# Altium schematic sheet High_Voltage.SchDoc(High_Voltage)
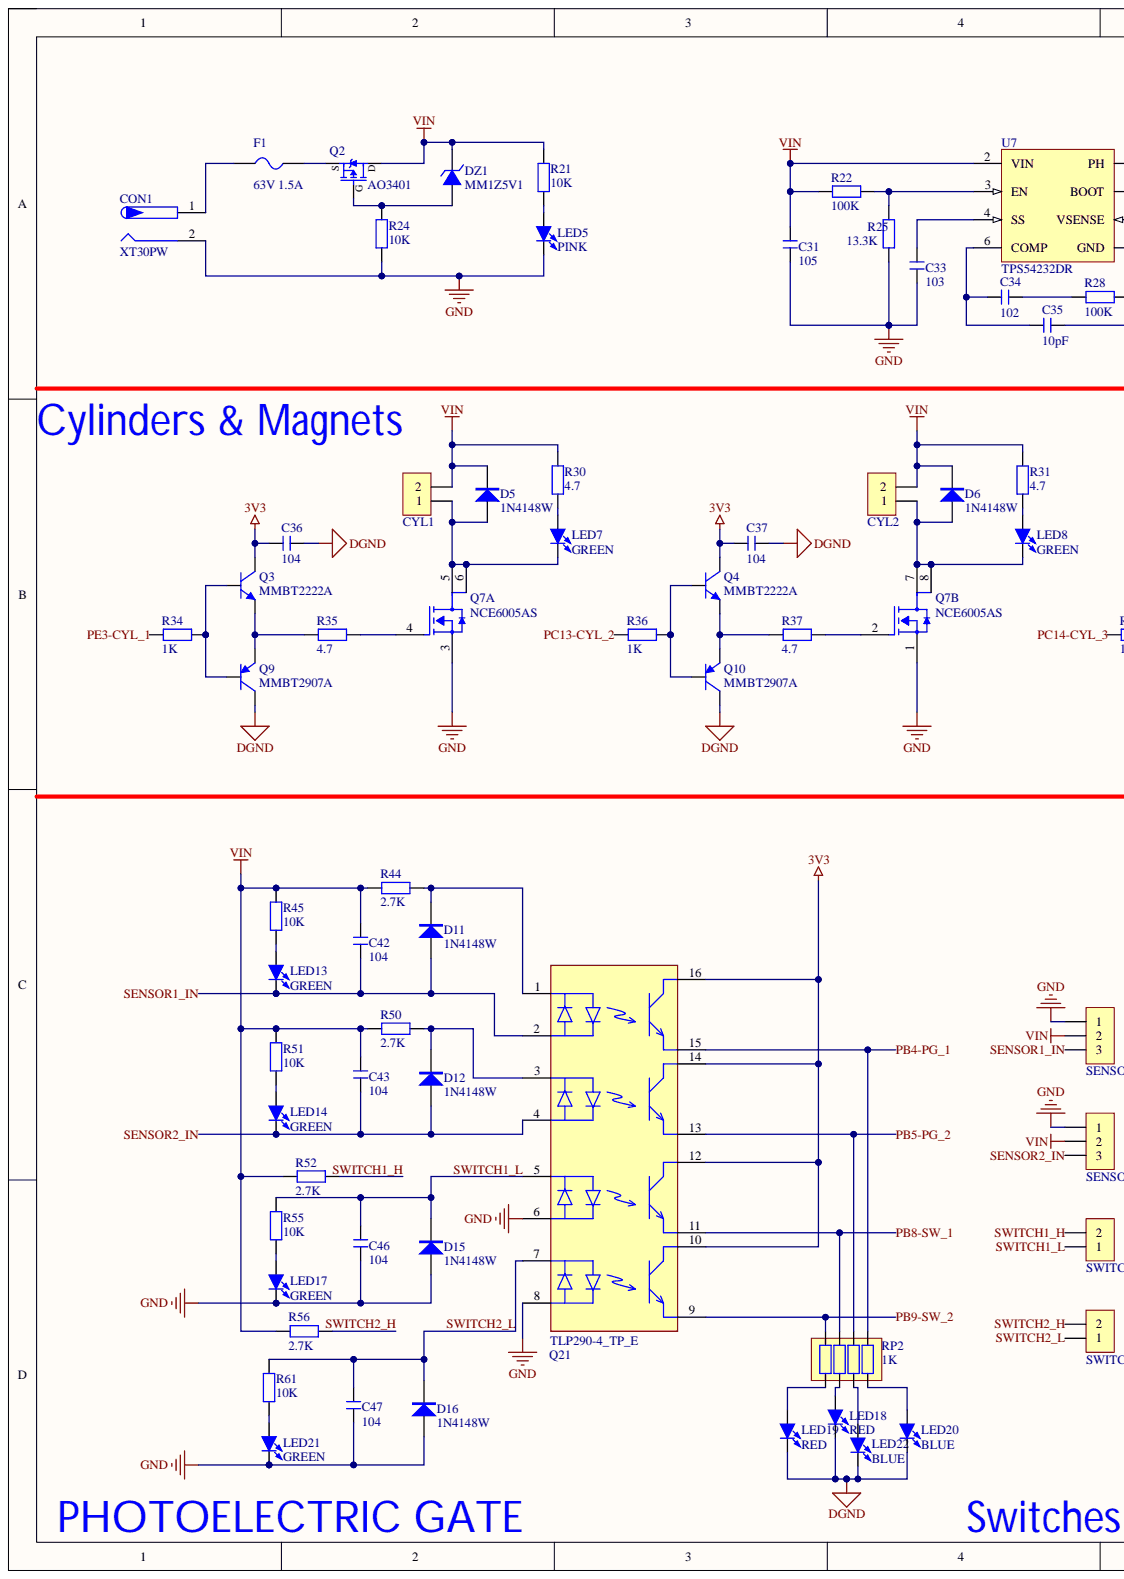
[diagram p0: High_Voltage.SchDoc, left]
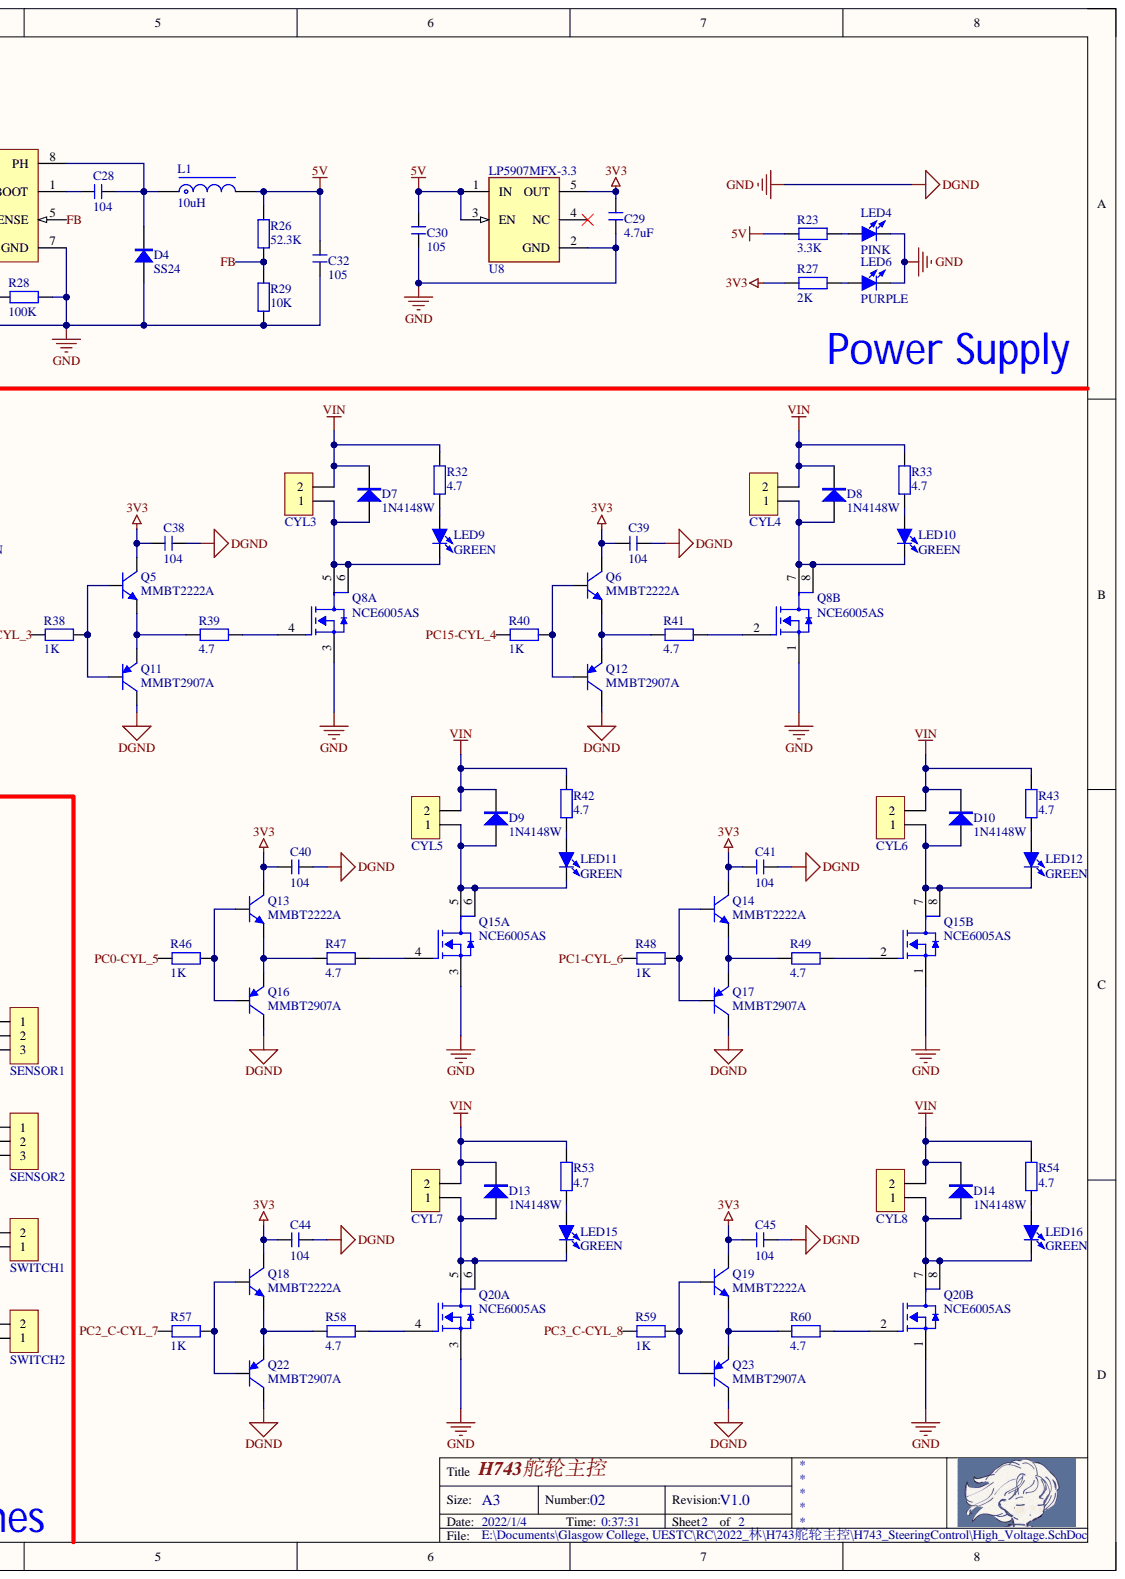
[diagram p1: High_Voltage.SchDoc, right]

## Parts
C28  104  [C 0603_L]
C29  4.7uF  [C 0603_L]
C30  105  [C 0603_L]
C31  105  [C 0805_L]
C32  105  [C 0805_L]
C33  103  [C 0603_L]
C34  102  [C 0603_L]
C35  10pF  [C 0603_L]
C36  104  [C 0603_L]
C37  104  [C 0603_L]
C38  104  [C 0603_L]
C39  104  [C 0603_L]
C40  104  [C 0603_L]
C41  104  [C 0603_L]
C42  104  [C 0603_L]
C43  104  [C 0603_L]
C44  104  [C 0603_L]
C45  104  [C 0603_L]
C46  104  [C 0603_L]
C47  104  [C 0603_L]
CON1  XT30PW  [XT30PW-F]
CYL1  HDR-1X2  [XH2.54-LS-2P]  (value not drawn)
CYL2  HDR-1X2  [XH2.54-LS-2P]  (value not drawn)
CYL3  HDR-1X2  [XH2.54-LS-2P]  (value not drawn)
CYL4  HDR-1X2  [XH2.54-LS-2P]  (value not drawn)
CYL5  HDR-1X2  [XH2.54-LS-2P]  (value not drawn)
CYL6  HDR-1X2  [XH2.54-LS-2P]  (value not drawn)
CYL7  HDR-1X2  [XH2.54-LS-2P]  (value not drawn)
CYL8  HDR-1X2  [XH2.54-LS-2P]  (value not drawn)
D4  SS24  [SMA]
D5  1N4148W  [SOD-123]
D6  1N4148W  [SOD-123]
D7  1N4148W  [SOD-123]
D8  1N4148W  [SOD-123]
D9  1N4148W  [SOD-123]
D10  1N4148W  [SOD-123]
D11  1N4148W  [SOD-123]
D12  1N4148W  [SOD-123]
D13  1N4148W  [SOD-123]
D14  1N4148W  [SOD-123]
D15  1N4148W  [SOD-123]
D16  1N4148W  [SOD-123]
DZ1  MM1Z5V1  [SOD-123]
F1  63V 1.5A  [FU 1206]
L1  10uH  [NR5040]
LED4  PINK  [LED 0603Y]
LED5  PINK  [LED 0603R]
LED6  PURPLE  [LED 0603Y]
LED7  GREEN  [LED 0603G]
LED8  GREEN  [LED 0603G]
LED9  GREEN  [LED 0603G]
LED10  GREEN  [LED 0603G]
LED11  GREEN  [LED 0603G]
LED12  GREEN  [LED 0603G]
LED13  GREEN  [LED 0603G]
LED14  GREEN  [LED 0603G]
LED15  GREEN  [LED 0603G]
LED16  GREEN  [LED 0603G]
LED17  GREEN  [LED 0603G]
LED18  RED  [LED 0603R]
LED19  RED  [LED 0603R]
LED20  BLUE  [LED 0603B]
LED21  GREEN  [LED 0603G]
LED22  BLUE  [LED 0603B]
Q2  AO3401  [SOT23-3N]
Q3  MMBT2222A  [SOT23-3L]
Q4  MMBT2222A  [SOT23-3L]
Q5  MMBT2222A  [SOT23-3L]
Q6  MMBT2222A  [SOT23-3L]
Q7  NCE6005AS  [Alpha_&_Omega_Semiconductor_Inc_SOIC-8_IPC_C]  (parts A,B)
Q8  NCE6005AS  [Alpha_&_Omega_Semiconductor_Inc_SOIC-8_IPC_C]  (parts A,B)
Q9  MMBT2907A  [SOT23-3L]
Q10  MMBT2907A  [SOT23-3L]
Q11  MMBT2907A  [SOT23-3L]
Q12  MMBT2907A  [SOT23-3L]
Q13  MMBT2222A  [SOT23-3L]
Q14  MMBT2222A  [SOT23-3L]
Q15  NCE6005AS  [Alpha_&_Omega_Semiconductor_Inc_SOIC-8_IPC_C]  (parts A,B)
Q16  MMBT2907A  [SOT23-3L]
Q17  MMBT2907A  [SOT23-3L]
Q18  MMBT2222A  [SOT23-3L]
Q19  MMBT2222A  [SOT23-3L]
Q20  NCE6005AS  [Alpha_&_Omega_Semiconductor_Inc_SOIC-8_IPC_C]  (parts A,B)
Q21  TLP290-4_TP_E  [SOP16_L]
Q22  MMBT2907A  [SOT23-3L]
Q23  MMBT2907A  [SOT23-3L]
R21  10K  [R 0603_L]
R22  100K  [R 0603_L]
R23  3.3K  [R 0603_L]
R24  10K  [R 0603_L]
R25  13.3K  [R 0603_L]
R26  52.3K  [R 0603_L]
R27  2K  [R 0603_L]
R28  100K  [R 0603_L]
R29  10K  [R 0603_L]
R30  4.7  [R 0603_L]
R31  4.7  [R 0603_L]
R32  4.7  [R 0603_L]
R33  4.7  [R 0603_L]
R34  1K  [R 0603_L]
R35  4.7  [R 0603_L]
R36  1K  [R 0603_L]
R37  4.7  [R 0603_L]
R38  1K  [R 0603_L]
R39  4.7  [R 0603_L]
R40  1K  [R 0603_L]
R41  4.7  [R 0603_L]
R42  4.7  [R 0603_L]
R43  4.7  [R 0603_L]
R44  2.7K  [R 0603_L]
R45  10K  [R 0603_L]
R46  1K  [R 0603_L]
R47  4.7  [R 0603_L]
R48  1K  [R 0603_L]
R49  4.7  [R 0603_L]
R50  2.7K  [R 0603_L]
R51  10K  [R 0603_L]
R52  2.7K  [R 0603_L]
R53  4.7  [R 0603_L]
R54  4.7  [R 0603_L]
R55  10K  [R 0603_L]
R56  2.7K  [R 0603_L]
R57  1K  [R 0603_L]
R58  4.7  [R 0603_L]
R59  1K  [R 0603_L]
R60  4.7  [R 0603_L]
R61  10K  [R 0603_L]
RP2  1K  [RCA-8P4R-0603]
SENSOR1  HDR-1X3  [XH2.54-LS-3P]  (value not drawn)
SENSOR2  HDR-1X3  [XH2.54-LS-3P]  (value not drawn)
SWITCH1  HDR-1X2  [XH2.54-LS-2P]  (value not drawn)
SWITCH2  HDR-1X2  [XH2.54-LS-2P]  (value not drawn)
U7  TPS54232DR  [SOP8_N]
U8  LP5907MFX-3.3  [Diodes_SOT25_MFG]

## Nets
3V3: C29.2 C36.1 C37.1 C38.1 C39.1 C40.1 C41.1 C44.1 C45.1 Q3.3 Q4.3 Q5.3 Q6.3 Q13.3 Q14.3 Q18.3 Q19.3 Q21.10 Q21.12 Q21.14 Q21.16 R27.2 U8.5(OUT)
5V: C30.2 C32.2 L1.2 R23.2 R26.1 U8.1(IN) U8.3(EN)
DGND: C29.1 C30.1 C31.1 C32.1 C33.2 C35.2 C36.2 C37.2 C38.2 C39.2 C40.2 C41.2 C44.2 C45.2 C46.1 C47.1 CON1.2 D4.1 D15.1 D16.1 LED4.2 LED5.2 LED6.2 LED17.2 LED18.2 LED19.2 LED20.2 LED21.2 LED22.2 Q7.1 Q7.3 Q8.1 Q8.3 Q9.3 Q10.3 Q11.3 Q12.3 Q15.1 Q15.3 Q16.3 Q17.3 Q20.1 Q20.3 Q21.6 Q21.8 Q22.3 Q23.3 R24.1 R25.1 R28.1 R29.2 SENSOR1.1 SENSOR2.1 U7.7(GND) U8.2(GND)
VIN: C31.2 C42.2 C43.2 CYL1.2 CYL2.2 CYL3.2 CYL4.2 CYL5.2 CYL6.2 CYL7.2 CYL8.2 D5.2 D6.2 D7.2 D8.2 D9.2 D10.2 D13.2 D14.2 DZ1.2 Q2.3 R21.2 R22.2 R30.2 R31.2 R32.2 R33.2 R42.2 R43.2 R44.1 R45.2 R50.1 R51.2 R52.1 R53.2 R54.2 R56.1 SENSOR1.2 SENSOR2.2 U7.2(VIN)
FB: R26.2 R29.1 U7.5(VSENSE)
PB4-PG_1: Q21.15 RP2.5
PB5-PG_2: Q21.13 RP2.6
PB8-SW_1: Q21.11 RP2.7
PB9-SW_2: Q21.9 RP2.8
PC0-CYL_5: R46.1
PC1-CYL_6: R48.1
PC2_C-CYL_7: R57.1
PC3_C-CYL_8: R59.1
PC13-CYL_2: R36.1
PC14-CYL_3: R38.1
PC15-CYL_4: R40.1
PE3-CYL_1: R34.1
SENSOR1_IN: C42.1 D11.1 LED13.2 Q21.2 SENSOR1.3
SENSOR2_IN: C43.1 D12.1 LED14.2 Q21.4 SENSOR2.3
SWITCH1_H: R52.2 SWITCH1.2
SWITCH1_L: C46.2 D15.2 Q21.5 R55.2 SWITCH1.1
SWITCH2_H: R56.2 SWITCH2.2
SWITCH2_L: C47.2 D16.2 Q21.7 R61.2 SWITCH2.1
NetC28_1: C28.1 U7.1(BOOT)
NetC28_2: C28.2 D4.2 L1.1 U7.8(PH)
NetC33_1: C33.1 U7.4(SS)
NetC34_1: C34.1 C35.1 U7.6(COMP)
NetC34_2: C34.2 R28.2
NetCON1_1: CON1.1 F1.1
NetCYL1_1: CYL1.1 D5.1 LED7.2 Q7.5 Q7.6
NetCYL2_1: CYL2.1 D6.1 LED8.2 Q7.7 Q7.8
NetCYL3_1: CYL3.1 D7.1 LED9.2 Q8.5 Q8.6
NetCYL4_1: CYL4.1 D8.1 LED10.2 Q8.7 Q8.8
NetCYL5_1: CYL5.1 D9.1 LED11.2 Q15.5 Q15.6
NetCYL6_1: CYL6.1 D10.1 LED12.2 Q15.7 Q15.8
NetCYL7_1: CYL7.1 D13.1 LED15.2 Q20.5 Q20.6
NetCYL8_1: CYL8.1 D14.1 LED16.2 Q20.7 Q20.8
NetD11_2: D11.2 Q21.1 R44.2
NetD12_2: D12.2 Q21.3 R50.2
NetDZ1_1: DZ1.1 Q2.1 R24.2
NetF1_2: F1.2 Q2.2
NetLED4_1: LED4.1 R23.1
NetLED5_1: LED5.1 R21.1
NetLED6_1: LED6.1 R27.1
NetLED7_1: LED7.1 R30.1
NetLED8_1: LED8.1 R31.1
NetLED9_1: LED9.1 R32.1
NetLED10_1: LED10.1 R33.1
NetLED11_1: LED11.1 R42.1
NetLED12_1: LED12.1 R43.1
NetLED13_1: LED13.1 R45.1
NetLED14_1: LED14.1 R51.1
NetLED15_1: LED15.1 R53.1
NetLED16_1: LED16.1 R54.1
NetLED17_1: LED17.1 R55.1
NetLED18_1: LED18.1 RP2.2
NetLED19_1: LED19.1 RP2.1
NetLED20_1: LED20.1 RP2.4
NetLED21_1: LED21.1 R61.1
NetLED22_1: LED22.1 RP2.3
NetQ3_1: Q3.1 Q9.1 R34.2
NetQ3_2: Q3.2 Q9.2 R35.1
NetQ4_1: Q4.1 Q10.1 R36.2
NetQ4_2: Q4.2 Q10.2 R37.1
NetQ5_1: Q5.1 Q11.1 R38.2
NetQ5_2: Q5.2 Q11.2 R39.1
NetQ6_1: Q6.1 Q12.1 R40.2
NetQ6_2: Q6.2 Q12.2 R41.1
NetQ7_2: Q7.2 R37.2
NetQ7_4: Q7.4 R35.2
NetQ8_2: Q8.2 R41.2
NetQ8_4: Q8.4 R39.2
NetQ13_1: Q13.1 Q16.1 R46.2
NetQ13_2: Q13.2 Q16.2 R47.1
NetQ14_1: Q14.1 Q17.1 R48.2
NetQ14_2: Q14.2 Q17.2 R49.1
NetQ15_2: Q15.2 R49.2
NetQ15_4: Q15.4 R47.2
NetQ18_1: Q18.1 Q22.1 R57.2
NetQ18_2: Q18.2 Q22.2 R58.1
NetQ19_1: Q19.1 Q23.1 R59.2
NetQ19_2: Q19.2 Q23.2 R60.1
NetQ20_2: Q20.2 R60.2
NetQ20_4: Q20.4 R58.2
NetR22_1: R22.1 R25.2 U7.3(EN)

Not connected: U8 4(NC)

## Library data of the parts
C28-C47 = C: 无极性贴片电容; 材质: X7R; BOM名称: 无极性贴片电容; Component Kind: Standard; Library Name: 3.1   - 电阻-电容-电感.SchLib
CON1 = XT30PW-M: XT30PW-M PCB板专用卧式连接器，低温升阻燃插头; Component Kind: Standard; Library Name: AMASS.IntLib
CYL1-CYL8 SWITCH1 SWITCH2 = Header 2: 2P接插件; BOM名称: 2P接插件; Component Kind: Standard; Library Name: 4.6   - 接插件-脚距2.54.SchLib
D4 = SS24: 肖特基二极管; 属性: 40V/2A; BOM名称: 肖特基二极管; Component Kind: Standard; Library Name: 8.1   - 二极管-整流桥.SchLib
D5-D16 = 1N4148: 高速开关二极管; BOM名称: 高速开关二极管; Component Kind: Standard; Library Name: 8.1   - 二极管-整流桥.SchLib
DZ1 = 1N4620: 3.3V/0.5W稳压管; BOM名称: 3.3V/0.5W稳压管; Component Kind: Standard; Library Name: 8.1   - 二极管-整流桥.SchLib
F1 = FU: 贴片保险丝; BOM名称: 贴片保险丝; Component Kind: Standard; Library Name: 10.1 - 保险丝-晶振.SchLib
L1 = L-NR: NR磁胶电感; BOM名称: NR磁胶电感; Component Kind: Standard; Library Name: 3.1   - 电阻-电容-电感.SchLib
LED4-LED22 = LED-SMD: 贴片LED; BOM名称: 贴片LED; Component Kind: Standard; Library Name: 9.1   - LED.SchLib
Q2 = AO3401: P沟道 30V/4.2A; BOM名称: 场效应管; Component Kind: Standard; Library Name: 6.1   - 场效应管-可控硅.SchLib; 描述: P沟道 30V/4.2A
Q3-Q6 Q13 Q14 Q18 Q19 = 8050-SMD: 高频放大-NPN型; 属性: 40V 1.5A 1W 100MHZ; BOM名称: 高频放大-NPN型; Component Kind: Standard; Library Name: 6.2   - 三极管.SchLib
Q7 Q8 Q15 Q20 = NCE6005AS: 60-V 5-A, N channel  power MOSFET, dual SO-8, 35 mOhm 8-SOIC -55 to 150; Component Kind: Standard; Library Name: 高级IC.IntLib
Q9-Q12 Q16 Q17 Q22 Q23 = 8550-SMD: 高频放大-PNP型; 属性: 40V 1.5A 1W 100MHZ; BOM名称: 高频放大-PNP型; Component Kind: Standard; Library Name: 6.2   - 三极管.SchLib
Q21 = TLP290-4_TP_E: Optocoupler AC-IN 4-CH Transistor DC-OUT 16-Pin SO T/R; Component Kind: Standard; Library Name: 高级IC.SchLib
R21-R61 = R: 贴片电阻; BOM名称: 贴片电阻; Component Kind: Standard; Library Name: 3.1   - 电阻-电容-电感.SchLib
RP2 = R-8P4R: 贴片网络排阻; BOM名称: 贴片网络排阻; Component Kind: Standard; Library Name: 3.1   - 电阻-电容-电感.SchLib
SENSOR1 SENSOR2 = Header 3: 3P接插件; BOM名称: 3P接插件; Component Kind: Standard; Library Name: 4.6   - 接插件-脚距2.54.SchLib
U7 = TPS54232DR: Buck Step Down Regulator with 3.5 to 28 V Input and 0.8 to 25 V Output, -40 to 150 degC, 8-Pin SOIC (D), Green (RoHS & no Sb/Br); Manufacturer: Texas Instruments; Duty Cycle(Max)(%): 90; Iout(Max)(A): 2; Iq(Typ)(mA): 0.11; Rating: Catalog; Regulated Outputs(#): 1; SubFamily: Step-Down Regulator; Switch Current Limit(Typ)(A): 2.3; Vin(Max)(V): 28; Vin(Min)(V): 3.5; Vout(Max)(V): 25; Vout(Min)(V): 0.8; Code_JEDEC: MS-012AA; Component Kind: Standard; ComponentLink1Description: Datasheet; ComponentLink1URL: http://www.ti.com/general/docs/lit/getliterature.tsp?genericPartNumber=TPS54232&fileType=pdf; ComponentLink2Description: URL Link1; ComponentLink2URL: Samples URL:http://www.ti.com/product/tps54232#samples; DatasheetVersion: SLVS876B; Eco-Plan: Green (RoHS & no Sb/Br); Mounting Technology: Surface Mount; PackageDescription: 8-Pin Plastic Small Outline Integrated Circuit, 4.9 x 3.9 mm, Pitch 1.27 mm Pitch; PackageReference: D8; PackageVersion: revM, Jun-2011; PartNumber: TPS54232D; Topology: Buck; Library Name: 高级IC.IntLib
U8 = LP5907MFX-3.3-NOPB: 250-mA ultra-low-noise low-IQ low-dropout (LDO) linear regulator 5-SOT-23 -40 to 125; Component Kind: Standard; Library Name: 高级IC.IntLib
